# Altium schematic sheet H05R1_Frontend_1.SchDoc(H05R1_Frontend_1)
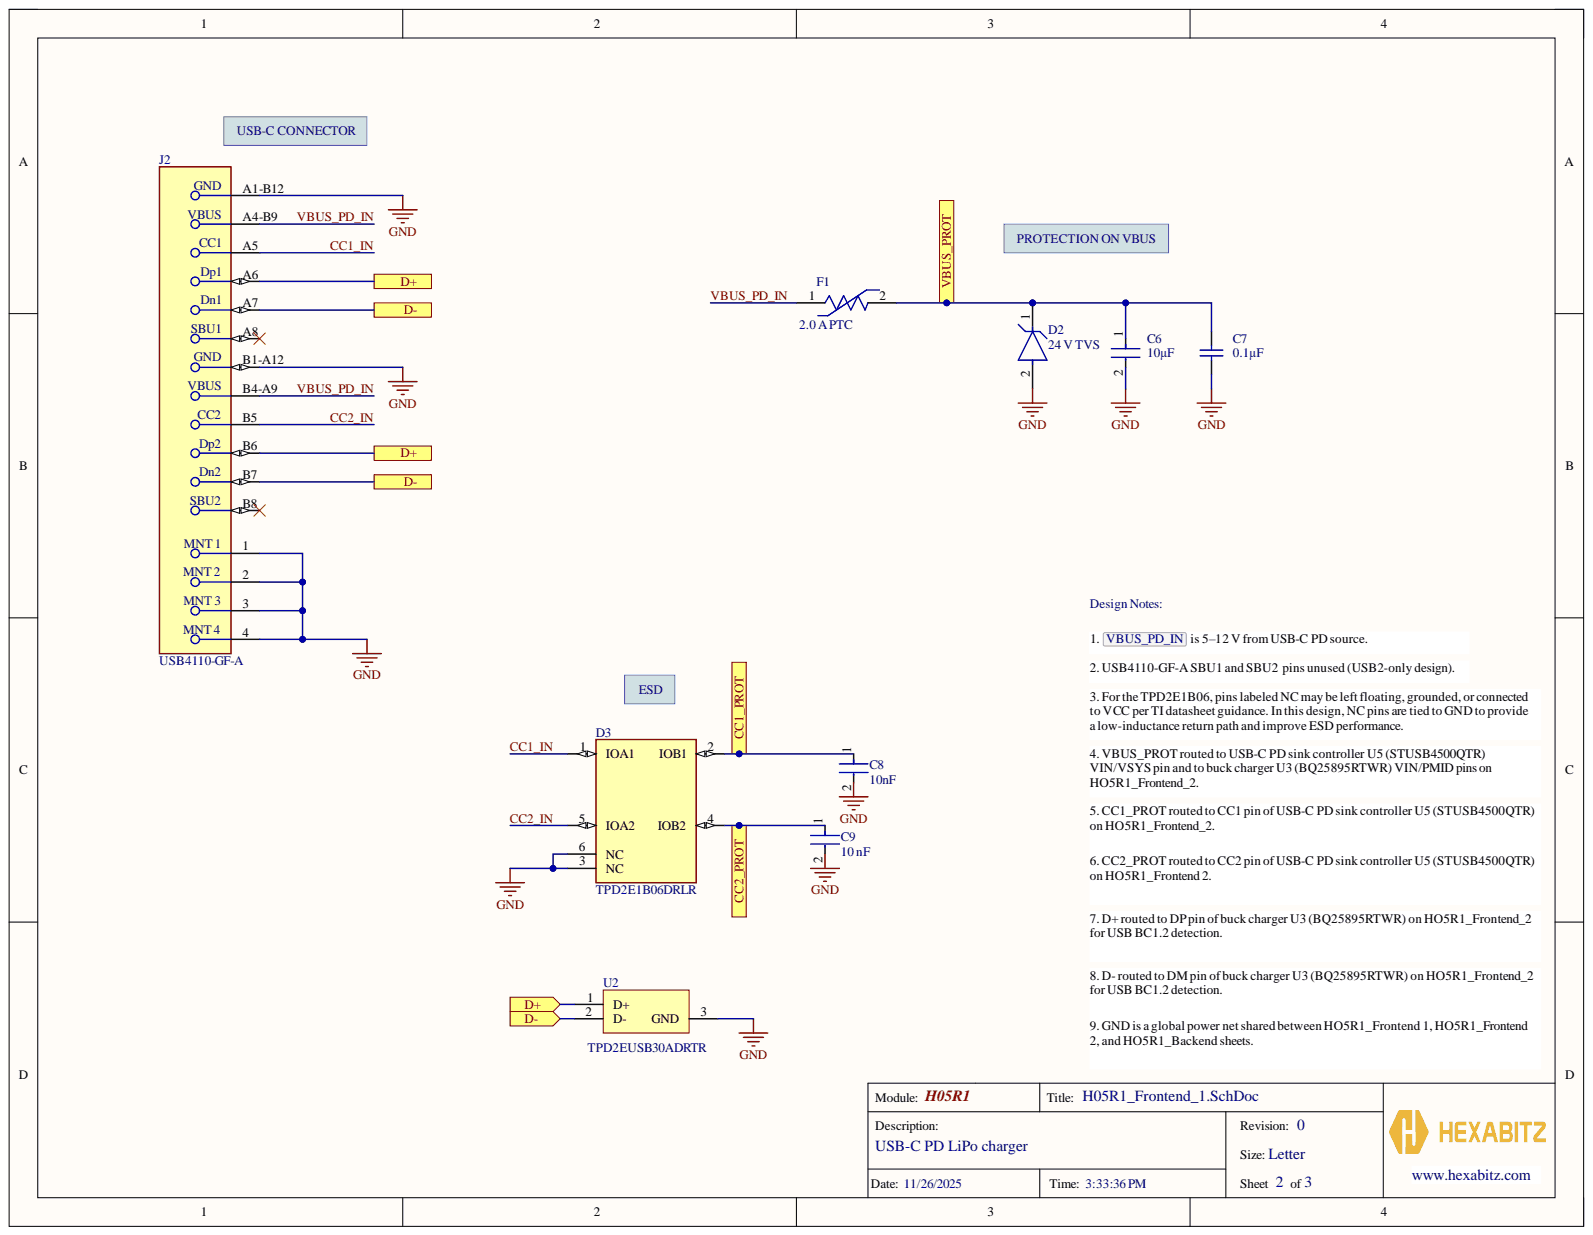
[diagram p0: H05R1_Frontend_1.SchDoc]

## Parts
C6  10µF  [FP-GRM32-MFG]
C7  0.1µF  [CAPC1608X90X35ML10T15]
C8  10nF  [FP-GRM155-0_05-IPC_C]
C9  10 nF  [FP-GRM155-0_05-IPC_C]
D2  24 V TVS  [FP-SMBJ-MFG]
D3  TPD2E1B06DRLR  [FP-DRL0006A-MFG]
F1  2.0 A PTC  [FP-0ZCF0200AF2C-MFG]
J2  USB4110-GF-A  [FP-USB4110-GF-A-MFG]
U2  TPD2EUSB30ADRTR  [DRT0003A]

## Ports
CC1_PROT, CC2_PROT, D+, D-, VBUS_PROT

## Nets
GND: C6.2 C7.1 C8.2 C9.2 D2.2 D3.3(NC) D3.6(NC) J2.1 J2.2 J2.3 J2.4 J2.A1-B12 J2.B1-A12 U2.3
CC1_IN: D3.1(IOA1) J2.A5
CC1_PROT: C8.1 D3.2(IOB1) ; port CC1_PROT
CC2_IN: D3.5(IOA2) J2.B5
CC2_PROT: C9.1 D3.4(IOB2) ; port CC2_PROT
VBUS_PD_IN: F1.1 J2.A4-B9 J2.B4-A9
VBUS_PROT: C6.1 C7.2 D2.1 F1.2 ; port VBUS_PROT
NetJ2_A6: J2.A6 J2.B6 U2.1
NetJ2_A7: J2.A7 J2.B7 U2.2

Not connected: J2 A8 B8

## Library data of the parts
C6 = CMP-2000-05261-2: CAP CER 10UF 50V X7R 1210; Manufacturer: Murata; Manufacturer Part Number: GRM32ER71H106KA12L; Material: Ceramic; Temperature Coefficient: 15%; Tolerance: 10%; Automotive: No; Capacitance: 10uF; Category: Cap; Component Kind: Standard; ComponentLink2Description: Datasheet; ComponentLink2URL: https://search.murata.co.jp/Ceramy/image/img/A01X/G101/ENG/GRM32ER71H106KA12-01.pdf; Device Class L1: Passive Components; Device Class L2: Capacitors; Device Class L3: Ceramic Capacitors; Digikey Description: CAP CER 10UF 50V X7R 1210; Height: 2.7mm; Lead Free: Yes; Library Name: Altium Content Vault; Mouser Description: 1210 10 uF 50 V ±10% Tolerance X7R SMT Multilayer Ceramic Capacitor; Octopart: https://octopart.com/grm32er71h106ka12l-murata-10470987; Octopartt: https://octopart.com/grm32er71h106ka12l-murata-10470987; Package: GRM32; RoHS: TRUE; Temperature: -55°C to +125°C; Temperature Characteristic: X7R; Temperature Range High: +125°C; Temperature Range Low: -55°C; Value: 10uF; Voltage Rating: 50V
C7 = CMP-2100-03643-1: Chip Capacitor, 0.1 uF, +/- 10%, 50 V, -55 to 125 degC, 0603 (1608 Metric), RoHS, Tape and Reel; Manufacturer: Murata; Capacitance: 100nF; Dielectric: X7R; Dielectric Material: Ceramic; Insulation Resistance: 10GR; Voltage: 50V; Case Code (Imperial): 0603; Case Code (Metric): 1608; Case/Package: 0603; Component Kind: Standard; Contact Plating: Tin; Datasheet URL: http://psearch.en.murata.com/capacitor/product/GRM188R71H104KA93%23.pdf; Datasheet Version: 42675.0; Depth: 0.8mm; Height: 0.8mm; Lead Free: LeadFree; Length: 1.6mm; Library Name: Altium Content Vault; Manufacturer URL: http://www.murata.com/; Material: Ceramic; Max Operating Temperature: 125degC; Min Operating Temperature: -55degC; Mount: SurfaceMount; Number of Pins: 2; Octopart: https://octopart.com/part/murata/GRM188R71H104KA93D; Package Description: 2-Pin Surface Mount Device, Body 1.6 x 0.8 mm; Package Quantity: 1; Package Reference: 0603; Packaging: TapeandReel; Radiation Hardening: No; REACH SVHC: NoSVHC; RoHSCompliant: true; Termination: SMD/SMT; Thickness: 0.035inch; Tolerance: 10%; Voltage Rating: 50V; Voltage Rating (DC): 50V; Width: 0.8mm
C8 = CMP-2008-04637-5: Chip Multilayer Ceramic Capacitors for General Purpose, 0402, 10000pF, X7R, 15%, 10%, 50V; MFN: Murata; Parameter 1: GRM155R71H103KA88D; Voltage: 25V; Capacitance: 10nF; Case Code (Imperial): 0402; Case Code (Metric): 1005; Case/Package: 0402; Component Kind: Standard; Depth: 0.5mm; Dielectric: X7R; Height: 0.5mm; Lead Free: Lead Free; Length: 1mm; Library Name: Altium Content Vault; Material: Ceramic; Max Operating Temperature: 125°C; Min Operating Temperature: -55°C; Mount: Surface Mount; Number of Pins: 2; Octopart: https://octopart.com/part/murata/GRM155R71H103KA88D; Package Quantity: 1; Packaging: Tape and Reel; Radiation Hardening: No; REACH SVHC: No SVHC; Termination: SMD/SMT; Thickness: 0.022inch; Tolerance: 10%; Voltage Rating: 50V; Voltage Rating (DC): 50V; Width: 0.02inch
C9 = CMP-2008-04637-5: Chip Multilayer Ceramic Capacitors for General Purpose, 0402, 10000pF, X7R, 15%, 10%, 50V; MPN: GRM155R71H103KA88D; Capacitance: 10nF; MFN: Murata; Voltage: 25V; Case Code (Imperial): 0402; Case Code (Metric): 1005; Case/Package: 0402; Component Kind: Standard; Depth: 0.5mm; Dielectric: X7R; Height: 0.5mm; Lead Free: Lead Free; Length: 1mm; Library Name: Altium Content Vault; Material: Ceramic; Max Operating Temperature: 125°C; Min Operating Temperature: -55°C; Mount: Surface Mount; Number of Pins: 2; Octopart: https://octopart.com/part/murata/GRM155R71H103KA88D; Package Quantity: 1; Packaging: Tape and Reel; Radiation Hardening: No; REACH SVHC: No SVHC; Termination: SMD/SMT; Thickness: 0.022inch; Tolerance: 10%; Voltage Rating: 50V; Voltage Rating (DC): 50V; Width: 0.02inch
D2 = CMP-07401-000035-1: TVS DIODE 24V 38.9V SMB; MPN: SMBJ24A; Breakdown Voltage: 30.7V; Diode Type: ZENER; Direction: Unidirectional; Element Configuration: Single; ESD Protection: Yes; Leakage Current: 5uA; Max Power Dissipation: 600W; Max Surge Current: 100A; MFN: Bourns; Min Breakdown Voltage: 26.7V; Number of Channels: 1; Operating Supply Voltage: 3.5V; Peak Pulse Current: 15.4A; Power Line Protection: No; Test Current: 1mA; Case/Package: SMB; Clamping Voltage: 38.9V; Component Kind: Standard; ComponentLink1Description: Octopart; ComponentLink1URL: https://octopart-clicks.com/click/altium?manufacturer=Bourns&mpn=SMBJ24A&country=US&channel=Vault+Part+Catalog&ref=man&; Height: 2.42mm; Length: 4.57mm; Library Name: Altium Content Vault; Max Breakdown Voltage: 30.7V; Max Operating Temperature: 150°C; Max Reverse Leakage Current: 5uA; Min Operating Temperature: -55°C; Mount: Surface Mount; Number of Elements: 1; Number of Pins: 2; Number of Unidirectional Channels: 1; Octopart: https://octopart.com/smbj24a-bourns-12809467; Package Quantity: 1; Packaging: Tape and Reel; Peak Pulse Power: 600W; Polarity: Unidirectional; Radiation Hardening: No; REACH SVHC: No SVHC; Resistor Type: Zener; Reverse Breakdown Voltage: 26.7V; Reverse Standoff Voltage: 24V; RoHS Compliant: Yes; Series: SMBJ; Termination: SMD/SMT; Width: 3.94mm
D3 = CMP-04985-000003-1: TVS DIODE 5.5V 15V 6SOT; Manufacturer: Texas Instruments; Breakdown Voltage: 7V; Direction: Bidirectional; Interface: I2C,USB,LVDS,Other; Number Of Channels: 2; Peak Pulse Current: 2.5A; Peak Pulse Power: 35W; Standoff Height: 0mm; Ambient Temperature Range High: +125°C; Ambient Temperature Range Low: -40°C; Automotive: No; Category: Diode; Clamping Voltage: 15V; Component Kind: Standard; ComponentLink2Description: Datasheet; ComponentLink2URL: http://www.ti.com/lit/ds/symlink/tpd2e1b06.pdf; Device Class L1: Circuit Protection; Device Class L2: ESD and Circuit Protection ICs; Device Class L3: unset; Digikey Description: TVS DIODE 5.5V 15V 6SOT; Height: 0.6mm; Lead Free: Yes; Library Name: Altium Content Vault; Manufacturer Part Number: TPD2E1B06DRLR; Mouser Description: ESD Suppressors / TVS Diodes 2-CH system level ESD protect device; Octopart: https://octopart.com/tpd2e1b06drlr-texas+instruments-30488860; Package: DRL0006A; Reverse Standoff Voltage: 5.5V; RoHS: TRUE; Temperature: -40°C to +125°C; Temperature Range High: +125°C; Temperature Range Low: -40°C
F1 = CMP-16043-000006-1: PTC RESET FUSE 24V 2A 2920; MPN: 0ZCF0200AF2C; Max Current Rating: 40A; MFN: Bel Fuse; Time to Trip: 5s; Trip Current: 4A; Case Code (Imperial): 2920; Case Code (Metric): 7351; Component Kind: Standard; ComponentLink1Description: Octopart; ComponentLink1URL: https://octopart-clicks.com/click/altium?manufacturer=Bel+Fuse&mpn=0ZCF0200AF2C&country=US&channel=Vault+Part+Catalog&ref=man&; Hold Current: 2A; Lead Free: Lead Free; Library Name: Altium Content Vault; Package Quantity: 1; Packaging: Cut Tape
J2 = CMP-245163-000002-1: CONN USB 2.0 TYPE-C R/A SMT; Manufacturer: GCT; Conn Orientation: Right Angle; Current Rating: 3/4.25/1.25/0.25A; Is Female: yes; Number Of Contacts: 16; Shielding: true; Standoff Height: 0.1mm; Usb Standard: USB 2.0; Voltage Rating: 20V; Automotive: No; Category: Conn; Component Kind: Standard; ComponentLink2Description: Datasheet; ComponentLink2URL: https://gct.co/files/drawings/usb4110.pdf; Contact Material: Gold,Nickel; Device Class L1: Connectors; Device Class L2: USB Connectors; Device Class L3: unset; Digikey Description: CONN USB 2.0 TYPE-C R/A SMT; Height: 3.46mm; Lead Free: Yes; Library Name: Altium Content Vault; Manufacturer Part Number: USB4110-GF-A; MPN: USB4110-GF-A; Octopart: https://octopart.com/usb4110-gf-a-gct-103941985; Package: 0; RoHS: TRUE; Temperature: -40°C to +85°C; Temperature Range High: +85°C; Temperature Range Low: -40°C
U2 = CMP-0326-00047-3: ESD / Surge Solution for High Speed, Low Voltage Interface, 2 Channels, -40 to +85 degC, 3-pin SOT (DRT), Green (RoHS & no Sb/Br); Manufacturer: Texas Instruments; 1394 / Firewire: TRUE; Breakdown Voltage(Min)(V): 4.5; Differential Capacitance(pF): 0.05; IEC 61000-4-2 Air-Gap(+/- kV): 8; IO Capacitance(Typ)(pF): 0.7; IO Leakage Current(nA): 10; SubFamily: ESD Solution; Component Kind: Standard; ComponentLink1Description: Datasheet; ComponentLink1URL: http://www.ti.com/general/docs/lit/getliterature.tsp?genericPartNumber=TPD2EUSB30A&fileType=pdf; ComponentLink2Description: URL Link1; ComponentLink2URL: SamplesURL:http://www.ti.com/product/tpd2eusb30a#samples; DatasheetVersion: SLVSAC2C; Eco-Plan: Green (RoHS & no Sb/Br); HDMI: TRUE; IEC 61000-4-2 Contact(+/- kV): 8; Library Name: Altium Content Vault; Mounting Technology: Surface Mount; Octopart: https://octopart.com/tpd2eusb30adrtr-texas+instruments-19659288; PackageDescription: 3-Flat Lead Plastic Small Outline, 1 x 1 mm (inc leads), 0.35 mm Pitch; PackageReference: DRT0003A; PackageVersion: revD, Jun-2008; PartNumber: TPD2EUSB30ADRTR; Serial ATA / eSATA: TRUE; USB Full Speed: TRUE; USB High Speed: TRUE; USB Super Speed: TRUE
